# Altium schematic sheet LEDs.SchDoc(LEDs)
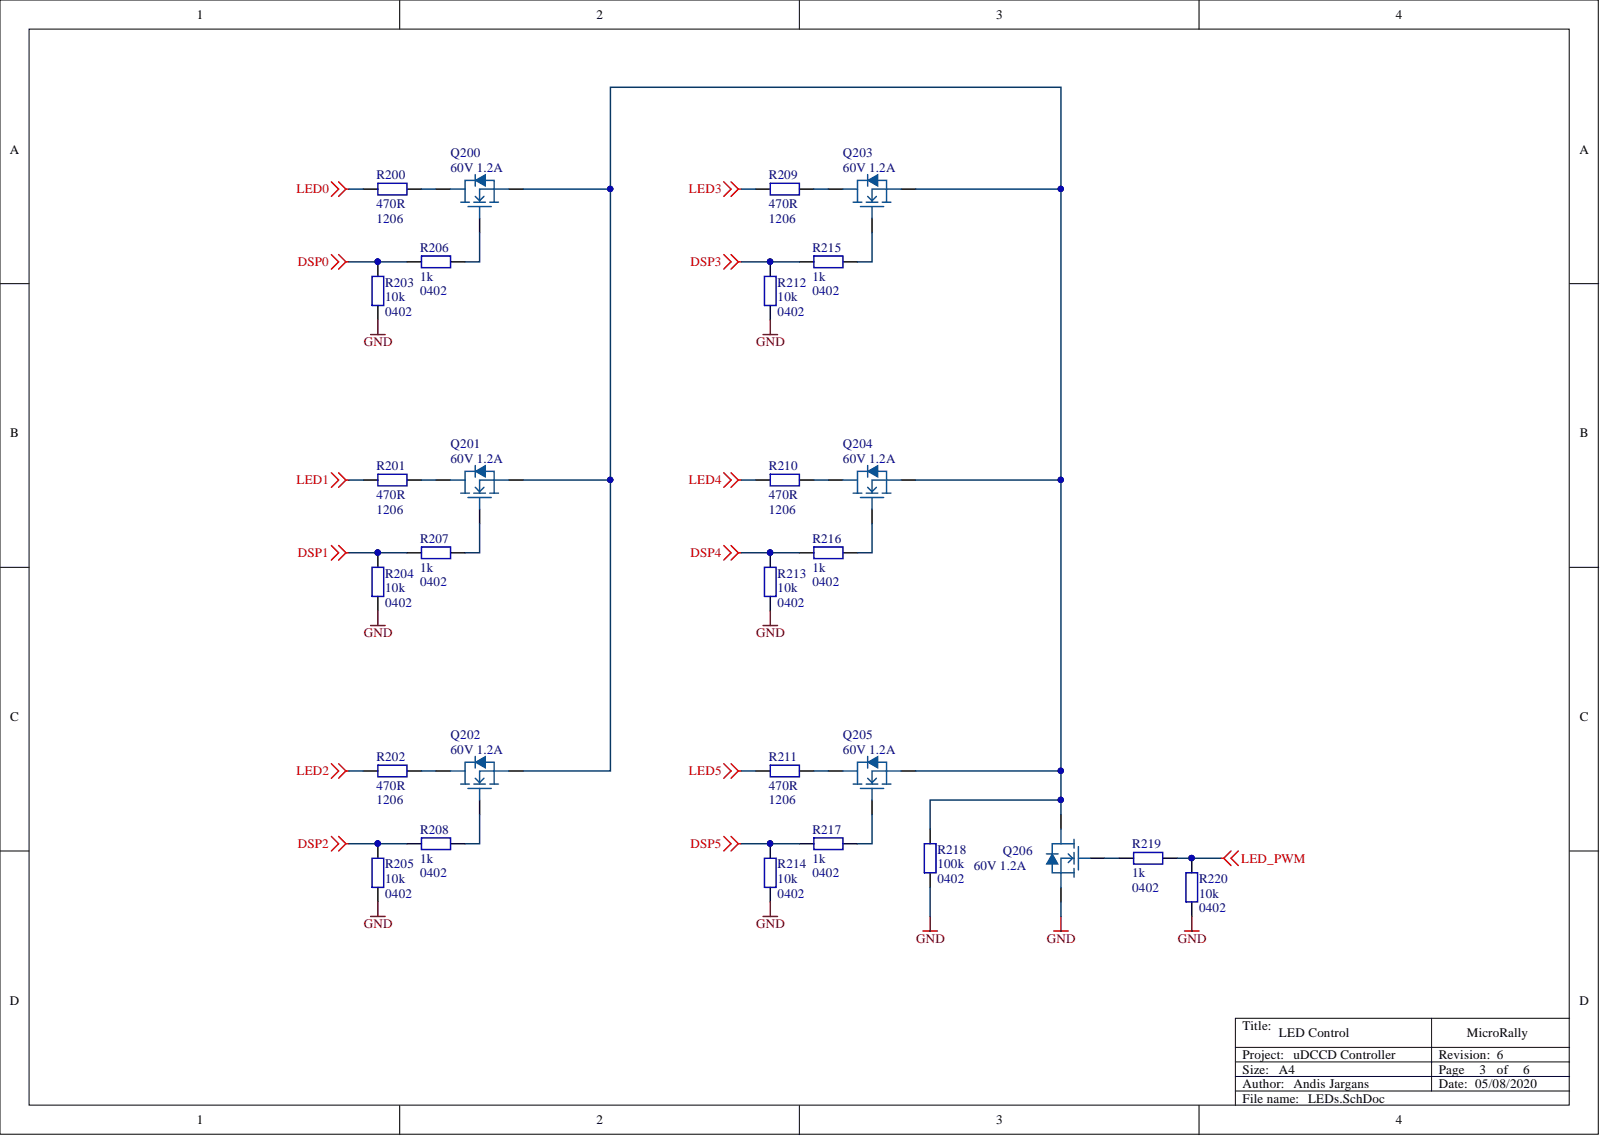
[diagram p0: LEDs.SchDoc]

## Parts
Q200  value 60V 1.2A  _VDS: 60V  _CURRENT: 1.2A  [SOT23-3]  (hidden comment: IRLML2060TRPBF)
Q201  value 60V 1.2A  _VDS: 60V  _CURRENT: 1.2A  [SOT23-3]  (hidden comment: IRLML2060TRPBF)
Q202  value 60V 1.2A  _VDS: 60V  _CURRENT: 1.2A  [SOT23-3]  (hidden comment: IRLML2060TRPBF)
Q203  value 60V 1.2A  _VDS: 60V  _CURRENT: 1.2A  [SOT23-3]  (hidden comment: IRLML2060TRPBF)
Q204  value 60V 1.2A  _VDS: 60V  _CURRENT: 1.2A  [SOT23-3]  (hidden comment: IRLML2060TRPBF)
Q205  value 60V 1.2A  _VDS: 60V  _CURRENT: 1.2A  [SOT23-3]  (hidden comment: IRLML2060TRPBF)
Q206  value 60V 1.2A  _CURRENT: 1.2A  [SOT23-3]  (hidden comment: IRLML2060TRPBF)
R200  470R  PACKAGE: 1206  [R 1206]
R201  470R  PACKAGE: 1206  [R 1206]
R202  470R  PACKAGE: 1206  [R 1206]
R203  10k  PACKAGE: 0402  [R 0402]
R204  10k  PACKAGE: 0402  [R 0402]
R205  10k  PACKAGE: 0402  [R 0402]
R206  1k  [R 0402]
R207  1k  [R 0402]
R208  1k  [R 0402]
R209  470R  PACKAGE: 1206  [R 1206]
R210  470R  PACKAGE: 1206  [R 1206]
R211  470R  PACKAGE: 1206  [R 1206]
R212  10k  PACKAGE: 0402  [R 0402]
R213  10k  PACKAGE: 0402  [R 0402]
R214  10k  PACKAGE: 0402  [R 0402]
R215  1k  [R 0402]
R216  1k  [R 0402]
R217  1k  [R 0402]
R218  100k  PACKAGE: 0402  [R 0402]
R219  1k  PACKAGE: 0402  [R 0402]
R220  10k  PACKAGE: 0402  [R 0402]

## Nets
DSP0: R203.2 R206.1
DSP1: R204.2 R207.1
DSP2: R205.2 R208.1
DSP3: R212.2 R215.1
DSP4: R213.2 R216.1
DSP5: R214.2 R217.1
GND: Q206.2 R203.1 R204.1 R205.1 R212.1 R213.1 R214.1 R218.1 R220.1
LED0: R200.1
LED1: R201.1
LED2: R202.1
LED3: R209.1
LED4: R210.1
LED5: R211.1
LED_PWM: R219.2 R220.2
NetQ200_1: Q200.1 R206.2
NetQ200_2: Q200.2 Q201.2 Q202.2 Q203.2 Q204.2 Q205.2 Q206.3 R218.2
NetQ200_3: Q200.3 R200.2
NetQ201_1: Q201.1 R207.2
NetQ201_3: Q201.3 R201.2
NetQ202_1: Q202.1 R208.2
NetQ202_3: Q202.3 R202.2
NetQ203_1: Q203.1 R215.2
NetQ203_3: Q203.3 R209.2
NetQ204_1: Q204.1 R216.2
NetQ204_3: Q204.3 R210.2
NetQ205_1: Q205.1 R217.2
NetQ205_3: Q205.3 R211.2
NetQ206_1: Q206.1 R219.1

## Library data of the parts
Q200-Q206 = Q MOS-N 60V 1.2A: MOSFET-N 60V 1.2A SOT23; _VGS Treshlod: 1.5V; _VGS: 16V; _POWER: 1.25W; PART#: IRLML2060TRPBF; PACKAGE: SOT23-3; Library Name: Transistors.IntLib; Component Kind: Standard; _TYPE: MOSFET-N
  Q206: _VDS: 60V
R200-R202 R209-R211 = R_470_1206: Resistor 1206 470 1/4W; _TOLERANCE: 1%; _POWER: 250mW; VALUE: 470R; Library Name: Resistors Chip.IntLib; Component Kind: Standard
R203-R205 R212-R214 R220 = R_10000_0402: Resistor 0402 10k 1/16W; _TOLERANCE: 1%; _POWER: 62.5mW; VALUE: 10k; Library Name: Resistors Chip.IntLib; Component Kind: Standard
R206-R208 R215-R217 R219 = R_1000_0402: Resistor 0402 1k 1/16W; _TOLERANCE: 1%; _POWER: 62.5mW; VALUE: 1k; Library Name: Resistors Chip.IntLib; Component Kind: Standard
  R206-R208 R215-R217: PACKAGE: 0402
R218 = R_100000_0402: Resistor 0402 100k 1/16W; _TOLERANCE: 1%; _POWER: 62.5mW; VALUE: 100k; Library Name: Resistors Chip.IntLib; Component Kind: Standard
